# Altium schematic sheet INPUT.SchDoc(INPUT)
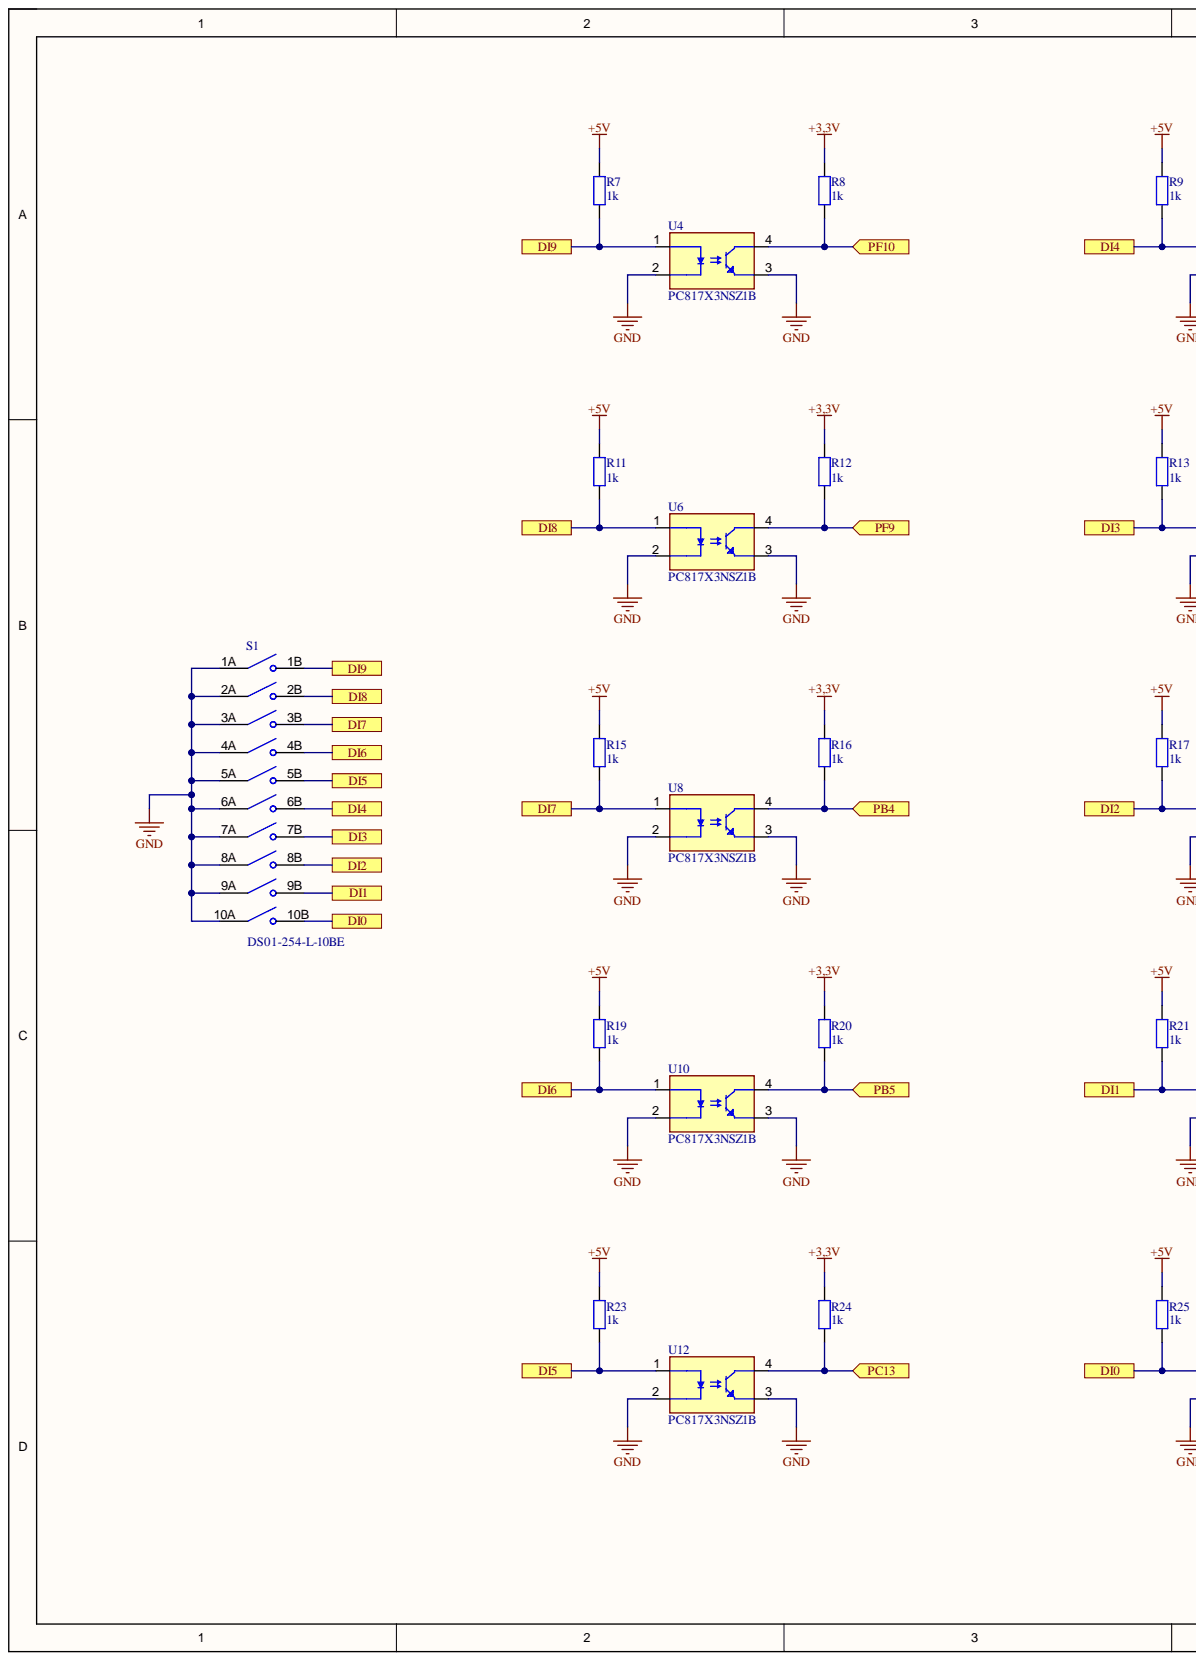
[diagram p0: INPUT.SchDoc, left]
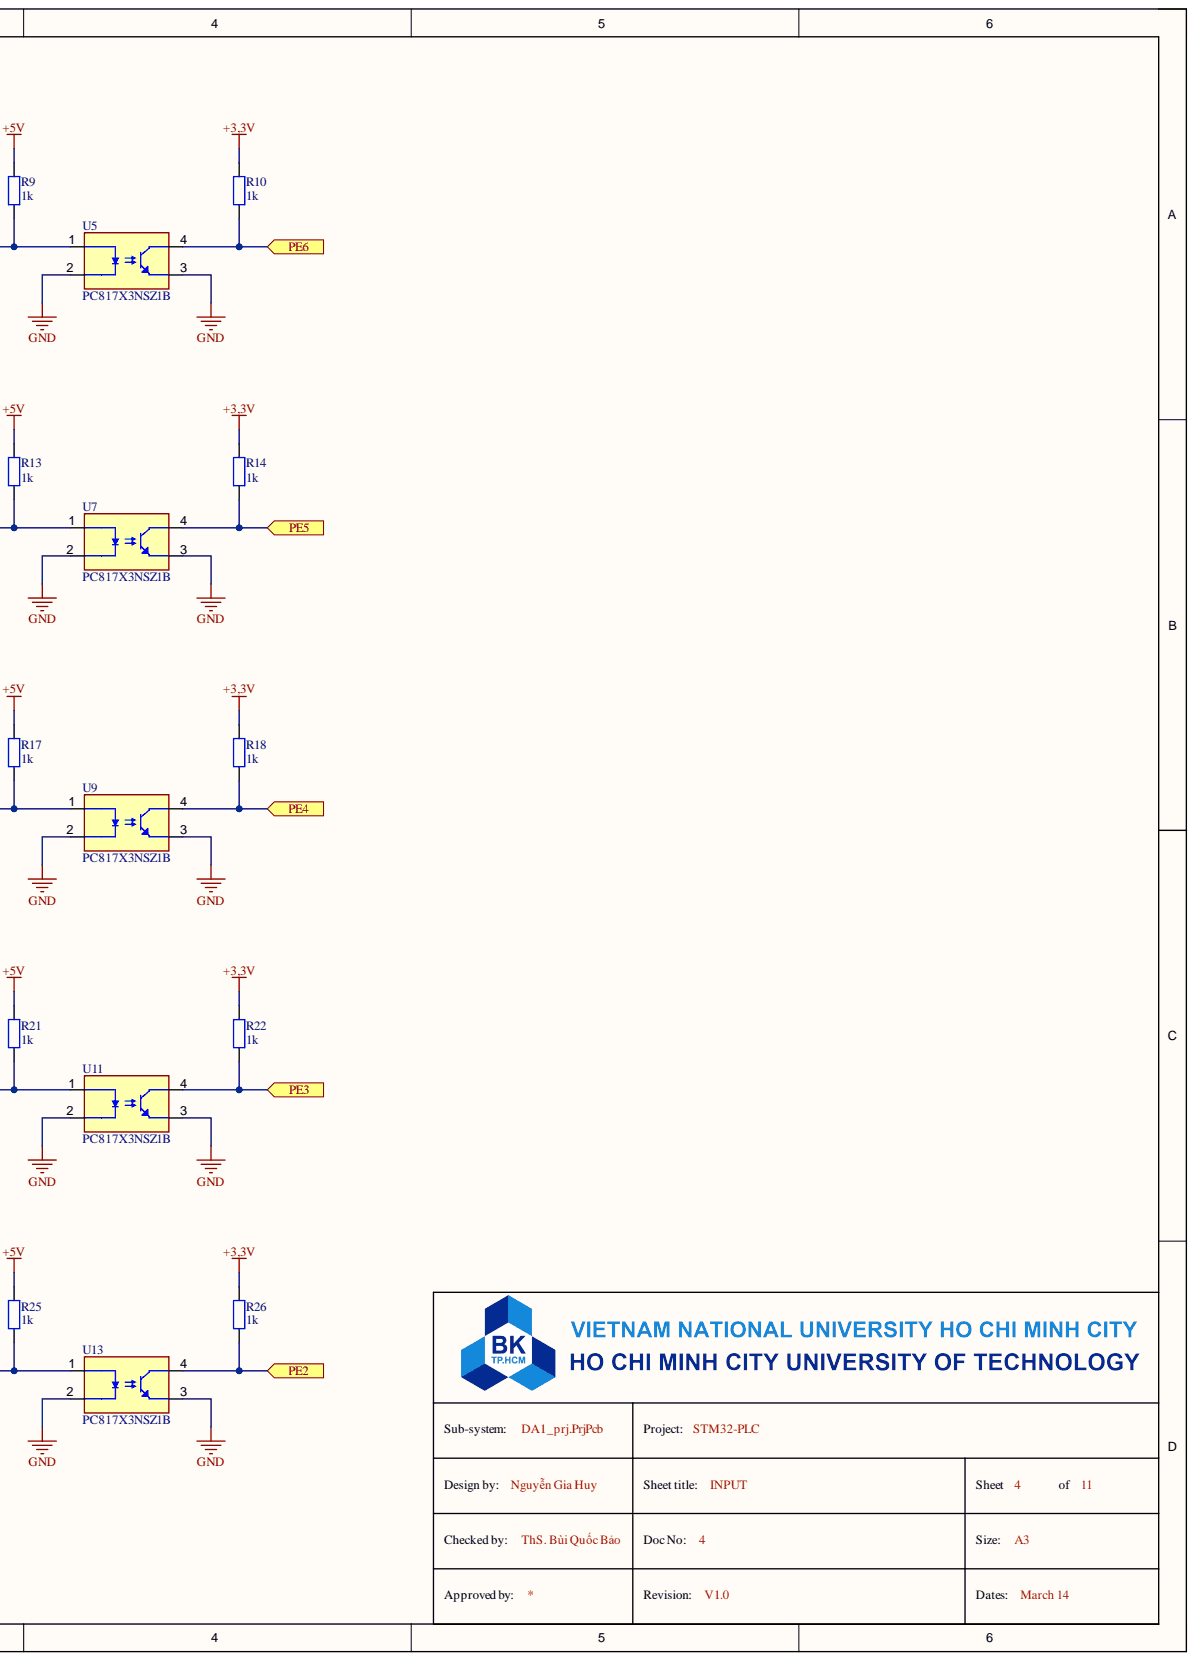
[diagram p1: INPUT.SchDoc, right]

## Parts
R7  1k  [tro smd 0603]
R8  1k  [tro smd 0603]
R9  1k  [tro smd 0603]
R10  1k  [tro smd 0603]
R11  1k  [tro smd 0603]
R12  1k  [tro smd 0603]
R13  1k  [tro smd 0603]
R14  1k  [tro smd 0603]
R15  1k  [tro smd 0603]
R16  1k  [tro smd 0603]
R17  1k  [tro smd 0603]
R18  1k  [tro smd 0603]
R19  1k  [tro smd 0603]
R20  1k  [tro smd 0603]
R21  1k  [tro smd 0603]
R22  1k  [tro smd 0603]
R23  1k  [tro smd 0603]
R24  1k  [tro smd 0603]
R25  1k  [tro smd 0603]
R26  1k  [tro smd 0603]
S1  DS01-254-L-10BE  [SW_DS01-254-L-10BE]
U4  PC817X3NSZ1B  [DIP762W50P254L495H455Q4]
U5  PC817X3NSZ1B  [DIP762W50P254L495H455Q4]
U6  PC817X3NSZ1B  [DIP762W50P254L495H455Q4]
U7  PC817X3NSZ1B  [DIP762W50P254L495H455Q4]
U8  PC817X3NSZ1B  [DIP762W50P254L495H455Q4]
U9  PC817X3NSZ1B  [DIP762W50P254L495H455Q4]
U10  PC817X3NSZ1B  [DIP762W50P254L495H455Q4]
U11  PC817X3NSZ1B  [DIP762W50P254L495H455Q4]
U12  PC817X3NSZ1B  [DIP762W50P254L495H455Q4]
U13  PC817X3NSZ1B  [DIP762W50P254L495H455Q4]

## Ports
DI0, DI1, DI2, DI3, DI4, DI5, DI6, DI7, DI8, DI9, PB4, PB5, PC13, PE2, PE3, PE4, PE5, PE6, PF9, PF10

## Nets
+3,3V: R8.2 R10.2 R12.2 R14.2 R16.2 R18.2 R20.2 R22.2 R24.2 R26.2
+5V: R7.2 R9.2 R11.2 R13.2 R15.2 R17.2 R19.2 R21.2 R23.2 R25.2
GND: S1.1A S1.2A S1.3A S1.4A S1.5A S1.6A S1.7A S1.8A S1.9A S1.10A U4.2 U4.3 U5.2 U5.3 U6.2 U6.3 U7.2 U7.3 U8.2 U8.3 U9.2 U9.3 U10.2 U10.3 U11.2 U11.3 U12.2 U12.3 U13.2 U13.3
DI0: R25.1 S1.10B U13.1 ; port DI0
DI1: R21.1 S1.9B U11.1 ; port DI1
DI2: R17.1 S1.8B U9.1 ; port DI2
DI3: R13.1 S1.7B U7.1 ; port DI3
DI4: R9.1 S1.6B U5.1 ; port DI4
DI5: R23.1 S1.5B U12.1 ; port DI5
DI6: R19.1 S1.4B U10.1 ; port DI6
DI7: R15.1 S1.3B U8.1 ; port DI7
DI8: R11.1 S1.2B U6.1 ; port DI8
DI9: R7.1 S1.1B U4.1 ; port DI9
PB4: R16.1 U8.4 ; port PB4
PB5: R20.1 U10.4 ; port PB5
PC13: R24.1 U12.4 ; port PC13
PE2: R26.1 U13.4 ; port PE2
PE3: R22.1 U11.4 ; port PE3
PE4: R18.1 U9.4 ; port PE4
PE5: R14.1 U7.4 ; port PE5
PE6: R10.1 U5.4 ; port PE6
PF9: R12.1 U6.4 ; port PF9
PF10: R8.1 U4.4 ; port PF10

## Library data of the parts
R7-R26 = Tro 0603 1% 10k: RES; Chung_Loai: Dán; Don_Vi: Con; Ma_Hang: MH006333; So_Chan: 2; Ten_Linh_Kien: Trở 0603 1% 10k; Component Kind: Standard; Library Name: AUSB.SchLib
S1 = DS01-254-L-10BE: 10 Position, Through Hole, 2.54 mm Pitch, Raised Slide Actuator, Flat Bottom, Long Pin, DIP Switch; MF: Same Sky; Check_prices: https://www.snapeda.com/parts/DS01-254-L-10BE/Same+Sky/view-part/?ref=eda; Component Kind: Standard; Library Name: DS01-254-L-10BE.IntLib; MP: DS01-254-L-10BE; Package: None; SnapEDA_Link: https://www.snapeda.com/parts/DS01-254-L-10BE/Same+Sky/view-part/?ref=snap
U4-U13 = PC817X3NSZ1B: Optoisolator Transistor Output - 1 Channel 4-DIP; MF: Sharp Microelectronics; Availability: In Stock; Check_prices: https://www.snapeda.com/parts/PC817X3NSZ1B/Sharp+Microelectronics/view-part/?ref=eda; Component Kind: Standard; Library Name: PC817X3NSZ1B.IntLib; MP: PC817X3NSZ1B; Package: DIP-4 Sharp Microelectronics; Price: None; Purchase-URL: https://pricing.snapeda.com/search/part/PC817X3NSZ1B/?ref=eda; SnapEDA_Link: https://www.snapeda.com/parts/PC817X3NSZ1B/Sharp+Microelectronics/view-part/?ref=snap
